# Altium schematic sheet Sensor.SchDoc (continued)

## Library data of the parts
C56 C60 C67 C69 C70 C72 C74 C88 C100 C103 C105 C135 C139 C164 = 1234105: CAP. SMD 1uF/16V X7R/X5R 0402 10%; Name Manufacturer#1: TDK; Name Manufacturer#2: TDK1; PN Manufacturer#1: C1005X5R1C105K0; PN Manufacturer#2: C1005X5R1C105KT; Database Table Name: ALTM_Capacitors; Component Kind: Standard; ComponentLink1Description: Datasheet; Cost: 0.0032; Crow Part Number: 1234105; Package: SMD; Signal Integrity: Model Name; Simulation: Model Name; Stock: 1709.96; Tolerance: 10%; Value: 1uF; Library Name: Database_Crow.DbLib
C57 C71 C73 C80 C81 C85 C89 C94 C101 C104 C125 C136 C137 C? C? = 1236106: CAP. SMD 10uF  0603 16V X5R  20%; Name Manufacturer#1: TDK; PN Manufacturer#1: PC1608X5R1C106M; Database Table Name: ALTM_Capacitors; Component Kind: Standard; ComponentLink1Description: Datasheet; Cost: 0.0306; Crow Part Number: 1236106; Package: SMD; Signal Integrity: Model Name; Simulation: Model Name; Stock: 33.18; Tolerance: 20%; Value: 10uF; Library Name: Database_Crow.DbLib
C58 C62 C78 C79 C87 C90 C95 C98 C99 C102 C138 C140 C142-C144 C147 C149 C165 C? C? C? C? = 1235104: CAP.SMD 100nF 10V X7R 0402 10%; Name Manufacturer#1: EYANG1; Name Manufacturer#2: KEMET; PN Manufacturer#1: 0402X104K100CT; PN Manufacturer#2: C0402C104K8RACT; Database Table Name: ALTM_Capacitors; Component Kind: Standard; ComponentLink1Description: Datasheet; Cost: 0.0005; Crow Part Number: 1235104; Package: SMD; Signal Integrity: Model Name; Simulation: Model Name; Tolerance: 10%; ComponentLink1URL: G:\SPEC\Capacitor\Ceramics\CAP SMD WALSIN.pdf; HelpURL: G:\SPEC\Capacitor\Ceramics\CAP SMD WALSIN.pdf; Library Name: Database_Crow.DbLib
  C58: Stock: 3236.71; Value: 100nF
  C62 C78 C79 C87 C90 C95 C98 C99 C102 C138 C140 C142-C144 C147 C149 C165 C? C? C?: Stock: 3379.81; Value: 100nF; Voltage: 10V
  C?: Stock: 3379.81; Voltage: 10V
C59 C63 C86 C? C? = 1230101: CAP. SMD 100PF COG 0402; Name Manufacturer#1: SAMSUNG; Name Manufacturer#2: SAMSUNG1; PN Manufacturer#1: CL05C101JB5NNNC; PN Manufacturer#2: CL05C101JB5NNND; Database Table Name: ALTM_Capacitors; Tolerance: 5%; Component Kind: Standard; ComponentLink1Description: Datasheet; Cost: 0.0006; Crow Part Number: 1230101; Package: SMD; Signal Integrity: Model Name; Simulation: Model Name; Stock: 1207.14; Value: 100pF; ComponentLink1URL: G:\SPEC\Capacitor\Ceramics\CAP SMD SAMSUNG.pdf; HelpURL: G:\SPEC\Capacitor\Ceramics\CAP SMD SAMSUNG.pdf; Library Name: Database_Crow.DbLib
C64 = 1234104: CAP. SMD 100nF/25V X5R 0402 20%; Name Manufacturer#1: HITANO; Name Manufacturer#2: MURATA@90; PN Manufacturer#1: 0402X104K250NU; PN Manufacturer#2: 1PGRM155R61E104; Database Table Name: ALTM_Capacitors; Component Kind: Standard; ComponentLink1Description: Datasheet; Cost: 0.001; Crow Part Number: 1234104; Package: SMD; Signal Integrity: Model Name; Simulation: Model Name; Stock: 877.91; Tolerance: 20%; Value: 100nF; ComponentLink1URL: G:\SPEC\Capacitor\NISKO  (High Capacitance) 0402X104M250CT.pdf; HelpURL: G:\SPEC\Capacitor\NISKO  (High Capacitance) 0402X104M250CT.pdf; Library Name: Database_Crow.DbLib
C65 C134 = 1236106: CAP. SMD 10uF  0603 16V X5R  20%; Name Manufacturer#1: MURATA; Name Manufacturer#2: TDK; PN Manufacturer#1: GRM188R61C106MA; PN Manufacturer#2: PC1608X5R1C106M; Database Table Name: ALTM_Capacitors; Component Kind: Standard; ComponentLink1Description: Datasheet; Cost: 0.0423; Crow Part Number: 1236106; Package: SMD; Signal Integrity: Model Name; Simulation: Model Name; Stock: 74.17; Tolerance: 20%; Value: 10uF; Library Name: Database_Crow.DbLib
C66 C68 C75 C76 C85 C153 C? C? = 1239476: CAP. SMD 47uF X5R 1206 10V 20%; Name Manufacturer#1: MURATA@90; Name Manufacturer#2: TDK; PN Manufacturer#1: 1PGRM31CR61A476; PN Manufacturer#2: C3216X5R1A476M1; Database Table Name: ALTM_Capacitors; Component Kind: Standard; ComponentLink1Description: Datasheet; Cost: 0.1019; Crow Part Number: 1239476; Package: SMD; Signal Integrity: Model Name; Simulation: Model Name; Stock: 4060; Tolerance: 20%; Value: 47uF; Library Name: Database_Crow.DbLib
C77 = 1234105: CAP. SMD 1uF/16V X7R/X5R 0402 10%; Name Manufacturer#1: TDK; Name Manufacturer#2: TDK1; PN Manufacturer#1: C1005X5R1C105K0; PN Manufacturer#2: C1005X5R1C105KT; Database Table Name: ALTM_Capacitors; Voltage: 16V; Component Kind: Standard; ComponentLink1Description: Datasheet; Cost: 0.0032; Crow Part Number: 1234105; Package: SMD; Signal Integrity: Model Name; Simulation: Model Name; Stock: 1709.96; Tolerance: 10%; Value: 1uF; Library Name: Database_Crow.DbLib
C91 C96 = 1234105: CAP. SMD 1uF/16V X7R/X5R 0402 10%; Name Manufacturer#1: MURATA@90; Name Manufacturer#2: KEMET; PN Manufacturer#1: 1PGRM155R61C105; PN Manufacturer#2: C0402C105K4PAC7; Database Table Name: ALTM_Capacitors; Component Kind: Standard; ComponentLink1Description: Datasheet; Cost: 0.0042; Crow Part Number: 1234105; Package: SMD; Signal Integrity: Model Name; Simulation: Model Name; Stock: 993.48; Tolerance: 10%; Value: 1uF; Library Name: Database_Crow.DbLib
C93 = 1235103: CAP. SMD 10nF X7R 0402 10%; Name Manufacturer#1: MURATA@90; Name Manufacturer#2: KEMET; PN Manufacturer#1: 1PGRM155R71H103; PN Manufacturer#2: C0402C103K5RACT; Database Table Name: ALTM_Capacitors; Component Kind: Standard; ComponentLink1Description: Datasheet; Cost: 0.0012; Crow Part Number: 1235103; Package: SMD; Signal Integrity: Model Name; Simulation: Model Name; Stock: 894.43; Tolerance: 10%; Value: 10nF; ComponentLink1URL: G:\SPEC\Capacitor\Ceramics\CAP SMD WALSIN.pdf; HelpURL: G:\SPEC\Capacitor\Ceramics\CAP SMD WALSIN.pdf; Library Name: Database_Crow.DbLib
C97 = C: X7R/X5R 0603; Component Kind: Standard; Package: 0603; Signal Integrity: Model Name; Simulation: Model Name; Value: 8.25uF; Library Name: Sch_Crow.SchLib
C98 = C: X7R/X5R; Value: 8.25uF; Component Kind: Standard; Package: 0603; Signal Integrity: Model Name; Simulation: Model Name; Library Name: Sch_Crow.SchLib
C145 C146 = 1235104: CAP.SMD 100nF 10V X7R 0402 10%; Name Manufacturer#1: MURATA@90; Name Manufacturer#2: KEMET; PN Manufacturer#1: 1PGRM155R71A104; PN Manufacturer#2: C0402C104K8RACT; Database Table Name: ALTM_Capacitors; Component Kind: Standard; ComponentLink1Description: Datasheet; Cost: 0.0007; Crow Part Number: 1235104; Package: SMD; Signal Integrity: Model Name; Simulation: Model Name; Stock: 13637.97; Tolerance: 10%; Value: 100nF; ComponentLink1URL: G:\SPEC\Capacitor\Ceramics\CAP SMD WALSIN.pdf; HelpURL: G:\SPEC\Capacitor\Ceramics\CAP SMD WALSIN.pdf; Library Name: Database_Crow.DbLib
C? C? C? C? = C: Component Kind: Standard; Signal Integrity: Model Name; Simulation: Model Name; Library Name: Sch_Crow.SchLib
J8 = CON HEAD 8P: Component Kind: Standard; Library Name: Sch_Crow.SchLib
J10 = 1612203: CONNECTOR SMD DUAL ROW 6 Pin HEADER 2X3 2.54mm; Name Manufacturer#1: AMTEK; PN Manufacturer#1: PH1M25-203GBCPR; Database Table Name: ALTM_Connectors; Value: CON 2X3; Component Kind: Standard; ComponentLink1Description: Datasheet; ComponentLink1URL: G:/SPEC/Connectors/AMTEK/5PH1XXAXX-X-3.pdf; Cost: 0.0843; Crow Part Number: 1612203; HelpURL: G:/SPEC/Connectors/AMTEK/5PH1XXAXX-X-3.pdf; Package: SMD; Stock: 421; Library Name: Database_Crow.DbLib
J11-J18 J20 J21 J27 J28 J? J? J? J? J? = 1612002: HEADER 2 PIN FOR PCB GOLD 2.54mm; Name Manufacturer#1: CIXI XINYA; Name Manufacturer#2: SYUIN; PN Manufacturer#1: 112-SS02G 2.54m; PN Manufacturer#2: 1701S-116-02G2; Database Table Name: ALTM_Connectors; Component Kind: Standard; ComponentLink1Description: Datasheet; Cost: 0.0029; Crow Part Number: 1612002; Package: TH; Stock: 33.29; Value: Header 2p,V.; ComponentLink1URL: G:\SPEC\Connectors\headers\1701S-118-XXG2(A)-suying.pdf; HelpURL: G:\SPEC\Connectors\headers\1701S-118-XXG2(A)-suying.pdf; Library Name: Database_Crow.DbLib
J19 = GMOS-RUN3_DIP40: Component Kind: Standard; Library Name: Sch_Crow.SchLib
R21 R53 R56 R57 R75 R? R? R? R? = 1120001: SMD RESISTOR 0 ohm 0402 5%; Name Manufacturer#1: VIKING; Name Manufacturer#2: PDC; PN Manufacturer#1: CR-02JL7----0R; PN Manufacturer#2: FCF02JV000; Database Table Name: ALTM_Resistors and Potentiometers; Power: 63mW; Component Kind: Standard; ComponentLink1Description: Datasheet; Cost: 0.0005; Crow Part Number: 1120001; Package: SMD; Signal Integrity: Model Name; Simulation: Model Name; Stock: 1404.73; Tolerance: 5%; Library Name: Database_Crow.DbLib
  R53 R56 R57 R75 R? R? R? R?: Value: 0R
R52 R58 R74 = 1120001: SMD RESISTOR 0 ohm 0402 5%; Name Manufacturer#1: VIKING; Name Manufacturer#2: PDC; PN Manufacturer#1: CR-02JL7----0R; PN Manufacturer#2: FCF02JV000; Database Table Name: ALTM_Resistors and Potentiometers; Power: 63mW; Value: 0R; Component Kind: Standard; ComponentLink1Description: Datasheet; Cost: 0.0005; Crow Part Number: 1120001; Package: SMD; Signal Integrity: Model Name; Simulation: Model Name; Stock: 1404.73; Tolerance: 5%; Library Name: Database_Crow.DbLib
R54 R55 R59 R60 R73 R77 = 1134102: SMD RESISTOR 1Kohm 0402 1%; Name Manufacturer#1: ROYAL OHM; Name Manufacturer#2: VIKING; PN Manufacturer#1: 0402WGF1001TCE; PN Manufacturer#2: CR-02FL6-1K; Database Table Name: ALTM_Resistors and Potentiometers; Power: 63mW; Component Kind: Standard; ComponentLink1Description: Datasheet; Cost: 0.0006; Crow Part Number: 1134102; Package: SMD; Signal Integrity: Model Name; Simulation: Model Name; Stock: 651.74; Tolerance: 1%; Value: 1K; Library Name: Database_Crow.DbLib
R61 R62 R66 R71 R72 R78 R79 = 1134103: SMD RESISTOR 10Kohm   0402 1%; Name Manufacturer#1: ROYAL OHM; Name Manufacturer#2: VIKING; PN Manufacturer#1: 0402WGF1002TCE; PN Manufacturer#2: CR-02FL7---10K; Database Table Name: ALTM_Resistors and Potentiometers; Power: 63mW; Component Kind: Standard; ComponentLink1Description: Datasheet; Cost: 0.0005; Crow Part Number: 1134103; Package: R0402/C; Signal Integrity: Model Name; Simulation: Model Name; Stock: 1169.97; Tolerance: 1%; Value: 10K; Library Name: Database_Crow.DbLib
R63 R64 = 1134204: SMD RESISTOR 200Kohm  0402 1%; Name Manufacturer#1: ROYAL OHM; Name Manufacturer#2: YAGEO; PN Manufacturer#1: 0402WGF2003TCE; PN Manufacturer#2: RC0402FR-07200K; Database Table Name: ALTM_Resistors and Potentiometers; Power: 63mW; Component Kind: Standard; ComponentLink1Description: Datasheet; Cost: 0.0006; Crow Part Number: 1134204; Package: SMD; Signal Integrity: Model Name; Simulation: Model Name; Stock: 139.84; Tolerance: 1%; Value: 200K; Library Name: Database_Crow.DbLib
R65 = 1134302: SMD RESISTOR 3Kohm  0402 1%; Name Manufacturer#1: YAGEO; PN Manufacturer#1: RC0402FR-073KL; Database Table Name: ALTM_Resistors and Potentiometers; Power: 63mW; Component Kind: Standard; ComponentLink1Description: Datasheet; Cost: 0.0008; Crow Part Number: 1134302; Package: SMD; Signal Integrity: Model Name; Simulation: Model Name; Stock: 9.45; Tolerance: 1%; Value: 3K; Library Name: Database_Crow.DbLib
R67 R69 = 1132332: RES. SMD 3.32KOHM 0603 1%; Name Manufacturer#1: YAGEO; PN Manufacturer#1: RC0603FR-073K32; Database Table Name: ALTM_Resistors and Potentiometers; Power: 63mW; Component Kind: Standard; ComponentLink1Description: Datasheet; Cost: 0.0006; Crow Part Number: 1132332; Package: SMD; Signal Integrity: Model Name; Simulation: Model Name; Stock: 7.6; Tolerance: 1%; Value: 3K32; Library Name: Database_Crow.DbLib
R68 = R: 7.87k 0402 1% E96; Component Kind: Standard; Package: 0402; Signal Integrity: Model Name; Simulation: Model Name; Value: 7.87K; Library Name: Sch_Crow.SchLib
R70 = 1132232: RES. SMD 2.32KOHM 0603 1%; Name Manufacturer#1: TMTEC; Name Manufacturer#2: YAGEO; PN Manufacturer#1: CR03FL72K32; PN Manufacturer#2: RC0603FR-072K32; Database Table Name: ALTM_Resistors and Potentiometers; Power: 63mW; Component Kind: Standard; ComponentLink1Description: Datasheet; Cost: 0.0006; Crow Part Number: 1132232; Package: SMD; Signal Integrity: Model Name; Simulation: Model Name; Stock: 7.83; Tolerance: 1%; Value: 2K32; Library Name: Database_Crow.DbLib
R80 R81 = 1134472: SMD RESISTOR 4.75Kohm  0402 1%; Name Manufacturer#1: ROYAL OHM; Name Manufacturer#2: YAGEO; PN Manufacturer#1: 0402WGF4751TCE; PN Manufacturer#2: RC0402FR-074K75; Database Table Name: ALTM_Resistors and Potentiometers; Power: 63mW; Value: 4K75; Component Kind: Standard; ComponentLink1Description: Datasheet; Cost: 0.0007; Crow Part Number: 1134472; Package: SMD; Signal Integrity: Model Name; Simulation: Model Name; Stock: 316.25; Tolerance: 1%; Library Name: Database_Crow.DbLib
R? R? R? R? R? R? = 1134105: SMD RESISTOR 1Mohm    0402 1%; Name Manufacturer#1: ROYAL OHM; Name Manufacturer#2: VIKING; PN Manufacturer#1: 0402WGF1004TCE; PN Manufacturer#2: CR-02FL7----1M; Database Table Name: ALTM_Resistors and Potentiometers; Power: 63mW; Component Kind: Standard; ComponentLink1Description: Datasheet; Cost: 0.0006; Crow Part Number: 1134105; Package: SMD; Signal Integrity: Model Name; Simulation: Model Name; Stock: 125.53; Tolerance: 1%; Library Name: Database_Crow.DbLib
  R? R? R? R?: Value: 1M
R? R? R? R? R? R? R? = 1122100: RESISTOR SMD   10 OHM 0805 5%; Name Manufacturer#1: EVER OHMS1; Name Manufacturer#2: ROYAL OHM; PN Manufacturer#1: 0805 5% 10R; PN Manufacturer#2: 0805S8J0100T5E; Database Table Name: ALTM_Resistors and Potentiometers; Power: 100mW; Component Kind: Standard; ComponentLink1Description: Datasheet; Cost: 0.004; Crow Part Number: 1122100; Package: SMD; Signal Integrity: Model Name; Simulation: Model Name; Stock: 67.19; Tolerance: 5%; Value: 10R; Library Name: Database_Crow.DbLib
R? R? = 1134402: SMD RESISTOR 4.02Kohm 0402 1%; Name Manufacturer#1: YAGEO; Name Manufacturer#2: SAMSUNG; PN Manufacturer#1: RC0402FR-074K02; PN Manufacturer#2: RC1005F4021CS; Database Table Name: ALTM_Resistors and Potentiometers; Power: 63mW; Component Kind: Standard; ComponentLink1Description: Datasheet; Cost: 0.0006; Crow Part Number: 1134402; Package: SMD; Signal Integrity: Model Name; Simulation: Model Name; Stock: 11.51; Tolerance: 1%; Value: 4K02; Library Name: Database_Crow.DbLib
RV2 RV? RV? = POT: 100K Multi turns; Manufacturer #1: Bourns; P/N: PV 36 W 104 C01 B00; Component Kind: Standard; Data sheet: https://www.bourns.com/docs/product-datasheets/pv36.pdf?sfvrsn=87259ff1_14; Signal Integrity: Model Name; Value: 100K; Library Name: Sch_Crow.SchLib
TP119-TP123 TP138-TP144 TP147 TP151 TP152 TP152 TP153 TP153 TP154 = TP-PROB: Library Name: Sch_Crow.SchLib
TP128 TP? = TP-HOLE: Library Name: Sch_Crow.SchLib
TP131 TP? = TP-1.8mm: Component Kind: Standard; Library Name: Sch_Crow.SchLib
U16 = DAC80004_TSSOP14: Component Kind: Standard; Value: DAC80004; Library Name: Sch_Crow.SchLib
U17-U20 = PMP5201Y: Transistor BJT NPN  SOT363; Component Kind: Standard; Value: PMP5201Y; Library Name: Sch_Crow.SchLib
U21 U30 = X60003_SOT23: X60003 precision 5v voltage reference; Component Kind: Standard; Value: X60003CIG3Z-50T1; Library Name: Sch_Crow.SchLib
U22 = LM334M_SO8: 3-Terminal Adjustable Current Source, 8-pin Narrow SOIC, Pb-Free; Manufacturer: National Semiconductor; CompType: MiscPower; High-Reliability: Y; PackageTopMarking: NSZXTT*LM334*M; PowerWise: N; Code_IPC: SOIC127P600-8; Code_JEDEC: MS-012-AA; Comment_1: LM334M/NOPB; Component Kind: Standard; ComponentLink1Description: Manufacturer URL; ComponentLink1URL: http://www.national.com/; ComponentLink2Description: LM334 Datasheet; ComponentLink2URL: http://www.national.com/ds/LM/LM334.pdf; ComponentLink3Description: LM334 Web Page; ComponentLink3URL: http://www.national.com/pf/LM/LM334.html; ComponentLink4Description: M08A Package Page; ComponentLink4URL: http://www.national.com/packaging/folders/m08a.html; DatasheetVersion: Mar-2005; PackageDescription: SOIC Narrow, 4.9 x 3.9 x 1.45mm, 8 Lead, 1.27mm Pitch; PackageReference: M08A; PackageVersion: revM, May-2009; PartNumber: LM334M/NOPB; PinCount: 8; RevisionNote: Sch pinout corrected.; RoHS: Y; Value: LM334M/NOPB; Library Name: Sch_Crow.SchLib
U23 = SSM2212RZ_SO8: Integrated Circuit; Manufacturer_Name: Analog Devices; Arrow Part Number: SSM2212RZ; Arrow Price/Stock: https://www.arrow.com/en/products/ssm2212rz/analog-devices; Component Kind: Standard; Datasheet Link: http://www.mouser.com/ds/2/609/SSM2212-879641.pdf; Height: 1.75mm; Manufacturer_Part_Number: SSM2212RZ; Mouser Part Number: 584-SSM2212RZ; Mouser Price/Stock: https://www.mouser.co.uk/ProductDetail/Analog-Devices/SSM2212RZ?qs=WIvQP4zGanisdksdPjFAGg%3D%3D; Value: SSM2212RZ; Library Name: Sch_Crow.SchLib
U24 = OPA2320SAIDGSR: High CMR, RRIO Operational Amplifier with Shutdown, 1.8 to 5.5 V, -40 to 125 degC, 10-pin SOP (DGS10), Green (RoHS & no Sb/Br); Component Kind: Standard; Library Name: Sch_Crow.SchLib
U25 U36 U? = 1359320: OP AMP Low-Noise with Shutdown OPA2320SAIDGSR; Name Manufacturer#1: TI; Database Table Name: ALTM_IC; Component Kind: Standard; ComponentLink1Description: Datasheet; ComponentLink1URL: G:/SPEC/IC TI/OPA2320.pdf; Crow Part Number: 1359320; HelpURL: G:/SPEC/IC TI/OPA2320.pdf; Package: SMD; PN Manufacturer#1: OPA2320SAIDGSR; Value: OPA2320SAIDGSR; Library Name: Database_Crow.DbLib
  U25 U36: Cost: 0.7881; Stock: 5.12
  U?: Cost: 0.8292; Stock: 4.85
U26 = ISL28534_TSSOP14: Component Kind: Standard; Value: ISL28534; Library Name: Sch_Crow.SchLib
U27 = CMP-04895-000011-1: IC OPAMP ZERO-DRIFT 2 CIRC 8SOIC; Manufacturer: Texas Instruments; Bandwidth: 8MHz; Gain Bandwidth: 14MHz; Input Bias Current: 0.0015uA; Input Offset Voltage: 0.0015mV; Max Dual Supply Voltage: 18V; Max Junction Temp: +150°C; Max Supply Voltage: 36V; Min Dual Supply Voltage: 2.25V; Min Supply Voltage: 4.5V; Nominal Supply Current: 1.3mA; Number Of Channels: 2; Standoff Height: 0.11mm; Voltage Gain: 170dB; Ambient Temperature Range High: +125°C; Ambient Temperature Range Low: -40°C; Automotive: No; Category: IC; Component Kind: Standard; ComponentLink2Description: Datasheet; ComponentLink2URL: http://www.ti.com/lit/ds/symlink/opa2189.pdf; Device Class L1: Integrated Circuits (ICs); Device Class L2: Linear ICs; Device Class L3: Amplifiers - Op Amps; Digikey Description: IC OPAMP ZERO-DRIFT 2 CIRC 8SOIC; Height: 1.75mm; Lead Free: Yes; Library Name: Altium Content Vault; Manufacturer Part Number: OPA2189IDR; Mouser Description: 36V Zero-Drift 14MHz MUX-Friendly Rail-to-Rail Output Operational Amplifier 8-Pin SOIC T/R; Octopart: https://octopart.com/opa2189idr-texas+instruments-85336227; Package: D0008A; RoHS: TRUE; Temperature: -40°C to +125°C; Temperature Range High: +125°C; Temperature Range Low: -40°C
U28 = CMP-04892-000031-1: IC SWITCH SINGLE 10VSSOP; Component Kind: Standard; Library Name: Altium Content Vault
U29 = ADS8355_WQFN16: The ADS8355 is a dual, high-speed, simultaneoussampling, analog-to-digital converter (ADC) that  supports single-ended and pseudo-differential analog  inputs.; Component Kind: Standard; Value: ADS8355; Library Name: Sch_Crow.SchLib
U31 = CMP-04892-000007-1: IC SWITCH SPST SOT23-5; Manufacturer: Texas Instruments; Max Junction Temp: +150°C; Max Supply Voltage: 5.5V; Min Supply Voltage: 1.65V; Nominal Supply Current: 0.01uA; Number Of Circuits: 1; On State Resistance: 0.7Ω; Standoff Height: 0mm; Throw Configuration: SPST-NO; Ambient Temperature Range High: +85°C; Ambient Temperature Range Low: -40°C; Automotive: No; Category: IC; Component Kind: Standard; ComponentLink2Description: Datasheet; ComponentLink2URL: http://www.ti.com/lit/ds/symlink/ts5a3166.pdf; Device Class L1: Integrated Circuits (ICs); Device Class L2: Interface ICs; Device Class L3: Analog Switches; Digikey Description: IC SWITCH SPST SOT23-5; Height: 1.45mm; Lead Free: Yes; Library Name: Altium Content Vault; Manufacturer Part Number: TS5A3166DBVR; Mouser Description: 1-Ohm SPST Analog Switch 5-V/3.3-V Single-Channel Analog Switch 5-SOT-23 -40 to 85; Octopart: https://octopart.com/ts5a3166dbvr-texas+instruments-512330; Package: DBV0005A; RoHS: TRUE; Temperature: -40°C to +85°C; Temperature Range High: +85°C; Temperature Range Low: -40°C
U35 = ISL60002_SOT23: Vref=2.5v    ISL60002BIH325Z-T7A OR                       ISL60002BIH325Z-TK                        ISL60002CIH325Z-T7A                        ISL60002CIH325Z-TK                        ISL60002DIH325Z-T7A                        ISL60002DIH325Z-TK; Component Kind: Standard; Data Sheet: https://www.renesas.com/eu/en/doc/datasheet/isl60002.pdf; Package: SOT23; Value: ISL60002CIH325Z-TK; Library Name: Sch_Crow.SchLib
U37 = OPA189_SO8: Component Kind: Standard; Value: OPA189IDR; Library Name: Sch_Crow.SchLib
U38-U40 U? = TPL0202-10MRTER: IC DGT POT 10KOHM 256TAP 16WQFN; Manufacturer_Name: Texas Instruments; Arrow Part Number: TPL0202-10MRTER; Arrow Price/Stock: https://www.arrow.com/en/products/tpl0202-10mrter/texas-instruments; Component Kind: Standard; Datasheet Link: http://www.ti.com/lit/gpn/tpl0202-10; Height: 0.8mm; Manufacturer_Part_Number: TPL0202-10MRTER; Mouser Part Number: 595-TPL0202-10MRTER; Mouser Price/Stock: https://www.mouser.com/Search/Refine.aspx?Keyword=595-TPL0202-10MRTER; Value: TPL0202-10MRTER; Library Name: Sch_Crow.SchLib
U41 = 1357300: HUMIDITY AND TEMPERATURE SENSOR HS3001; Name Manufacturer#1: IDT; Database Table Name: ALTM_IC; Component Kind: Standard; ComponentLink1Description: Datasheet; ComponentLink1URL: G:/SPEC/HUMIDITY SENSOR/IDT_HS300x-Datasheet_DST_20180806.pdf; Cost: 0; Crow Part Number: 1357300; HelpURL: G:/SPEC/HUMIDITY SENSOR/IDT_HS300x-Datasheet_DST_20180806.pdf; Package: SMD; PN Manufacturer#1: HS3001; Stock: 0; Value: HS3001; Library Name: Database_Crow.DbLib
U? = LTC6269IDD_DFN10: Linear: Dual 500MHz Ultra-Low Bias Current FET Input Op Amp; Component Kind: Standard; Value: LTC6269IDD; Library Name: Sch_Crow.SchLib
TP113-TP118 TP126 TP127 TP129 TP130 TP132-TP137 = TP-1.5MM: Library Name: Database_Crow.DbLib
